# Altium schematic sheet F4_100pin.SchDoc
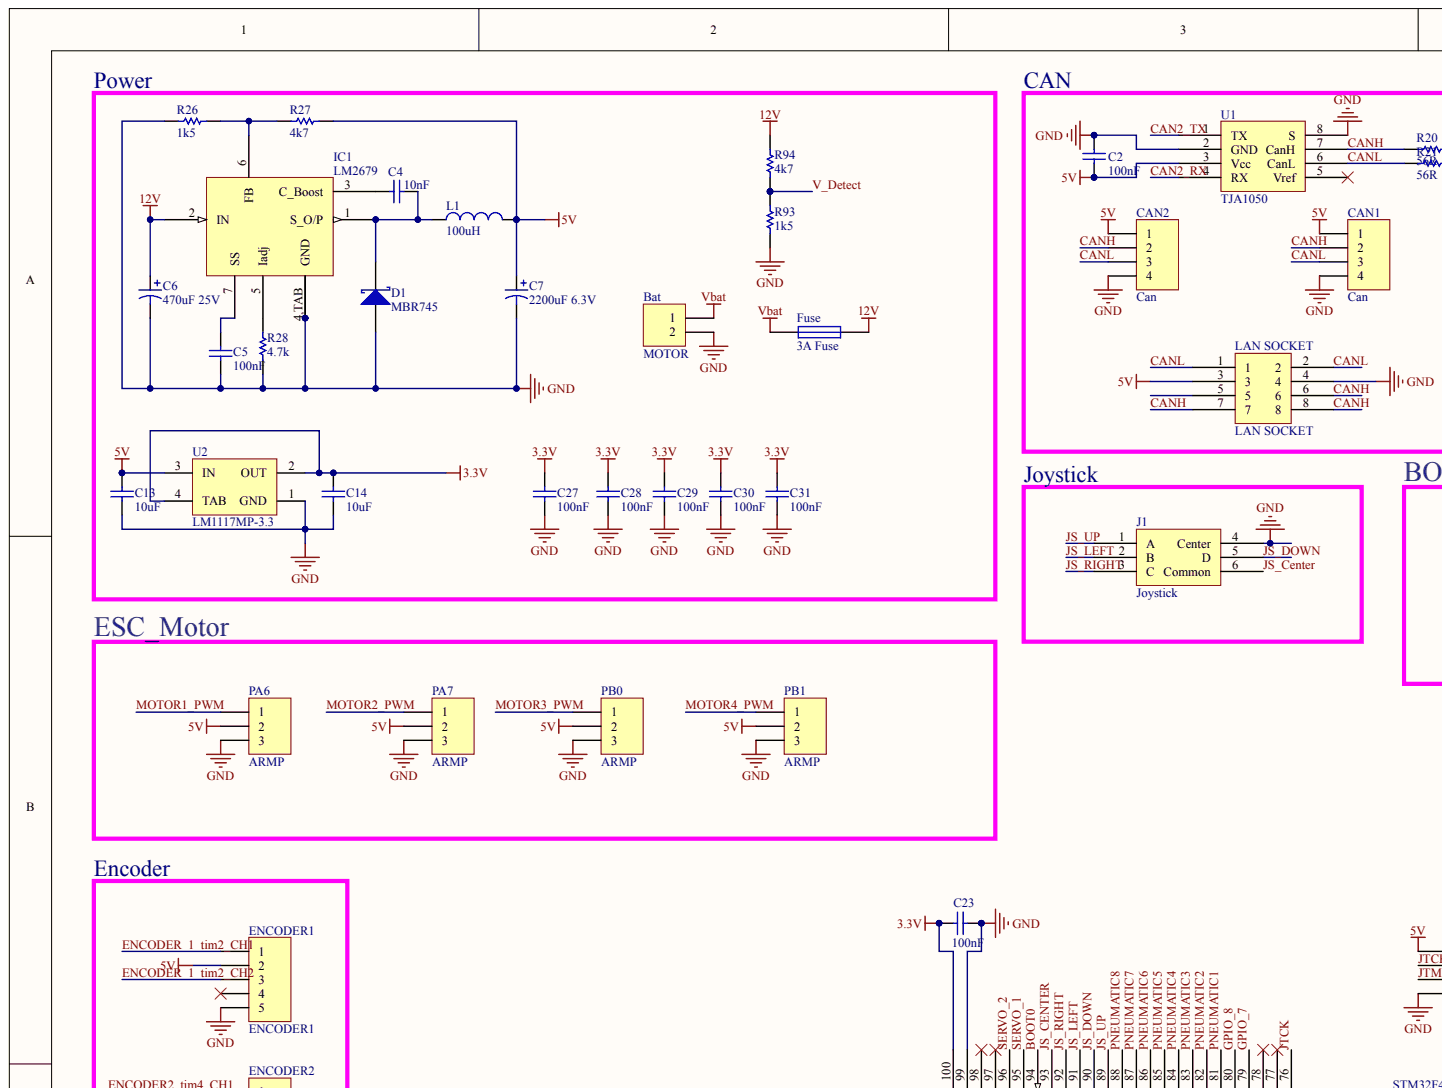
[diagram p0: F4_100pin.SchDoc, top left]
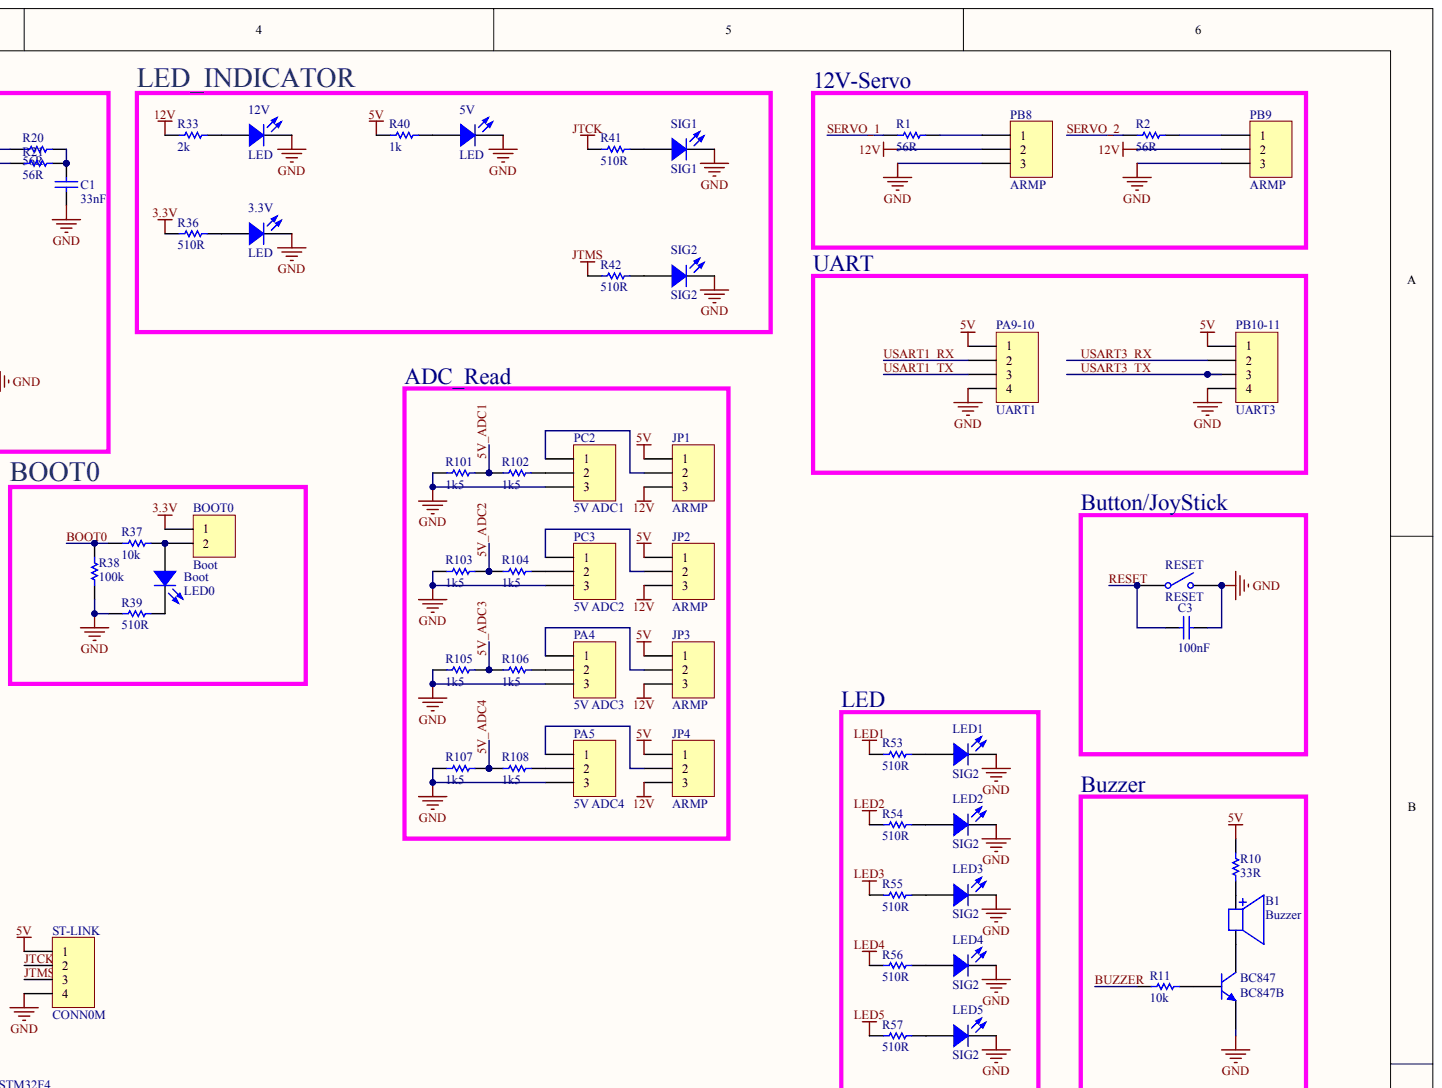
[diagram p1: F4_100pin.SchDoc, top right]
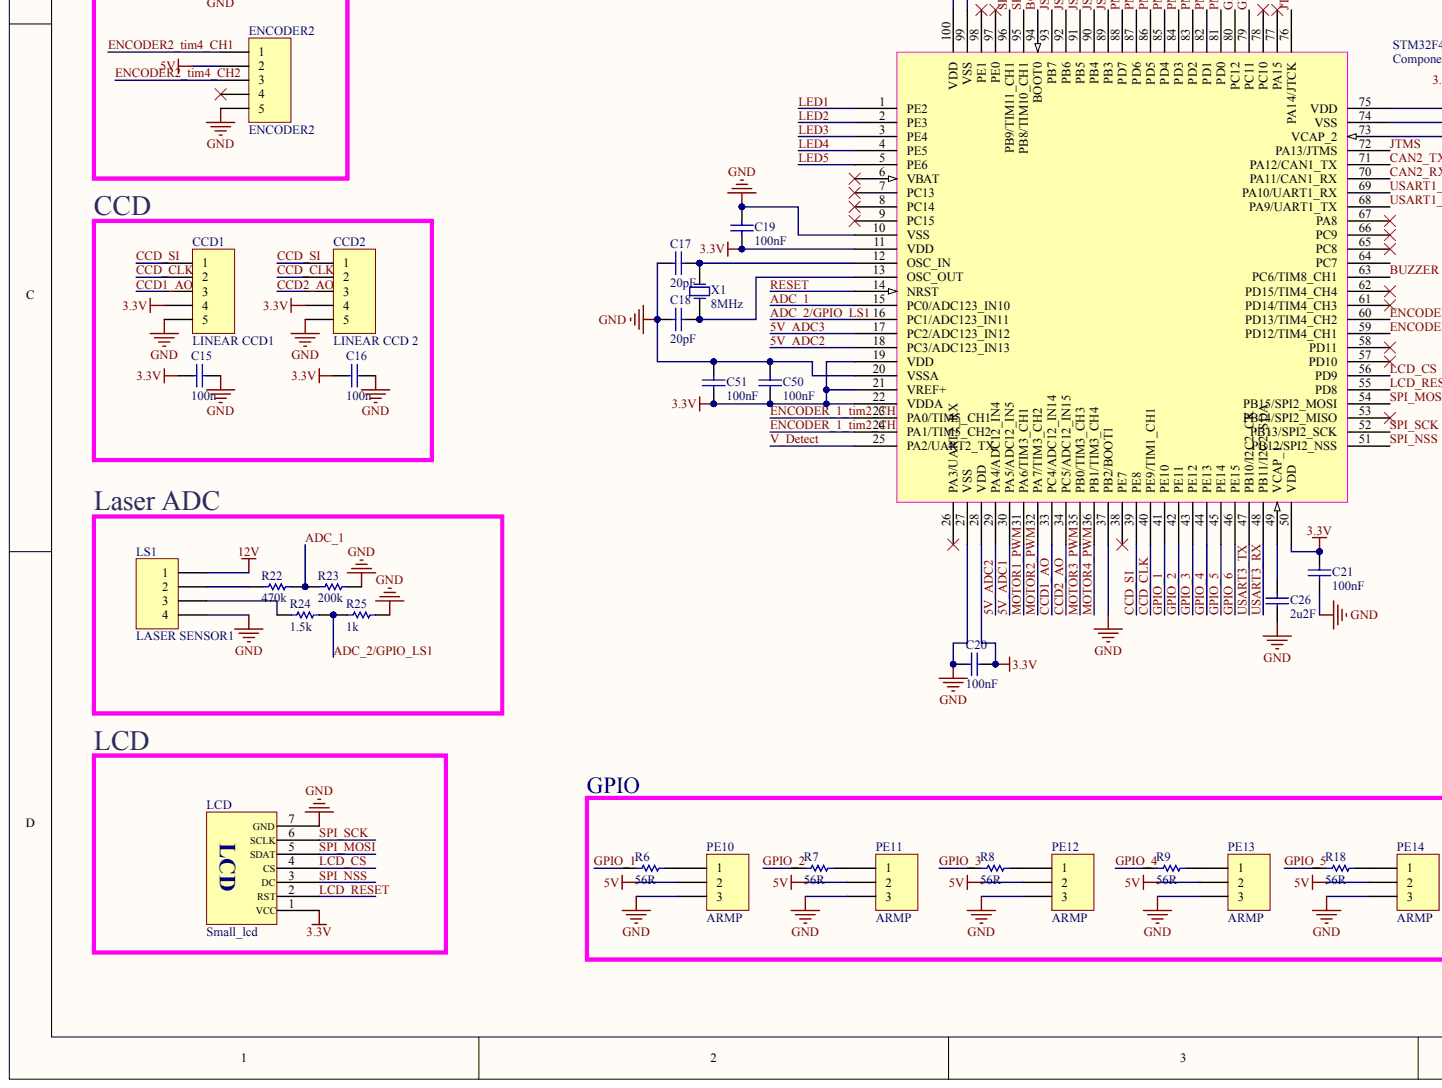
[diagram p2: F4_100pin.SchDoc, bottom left]
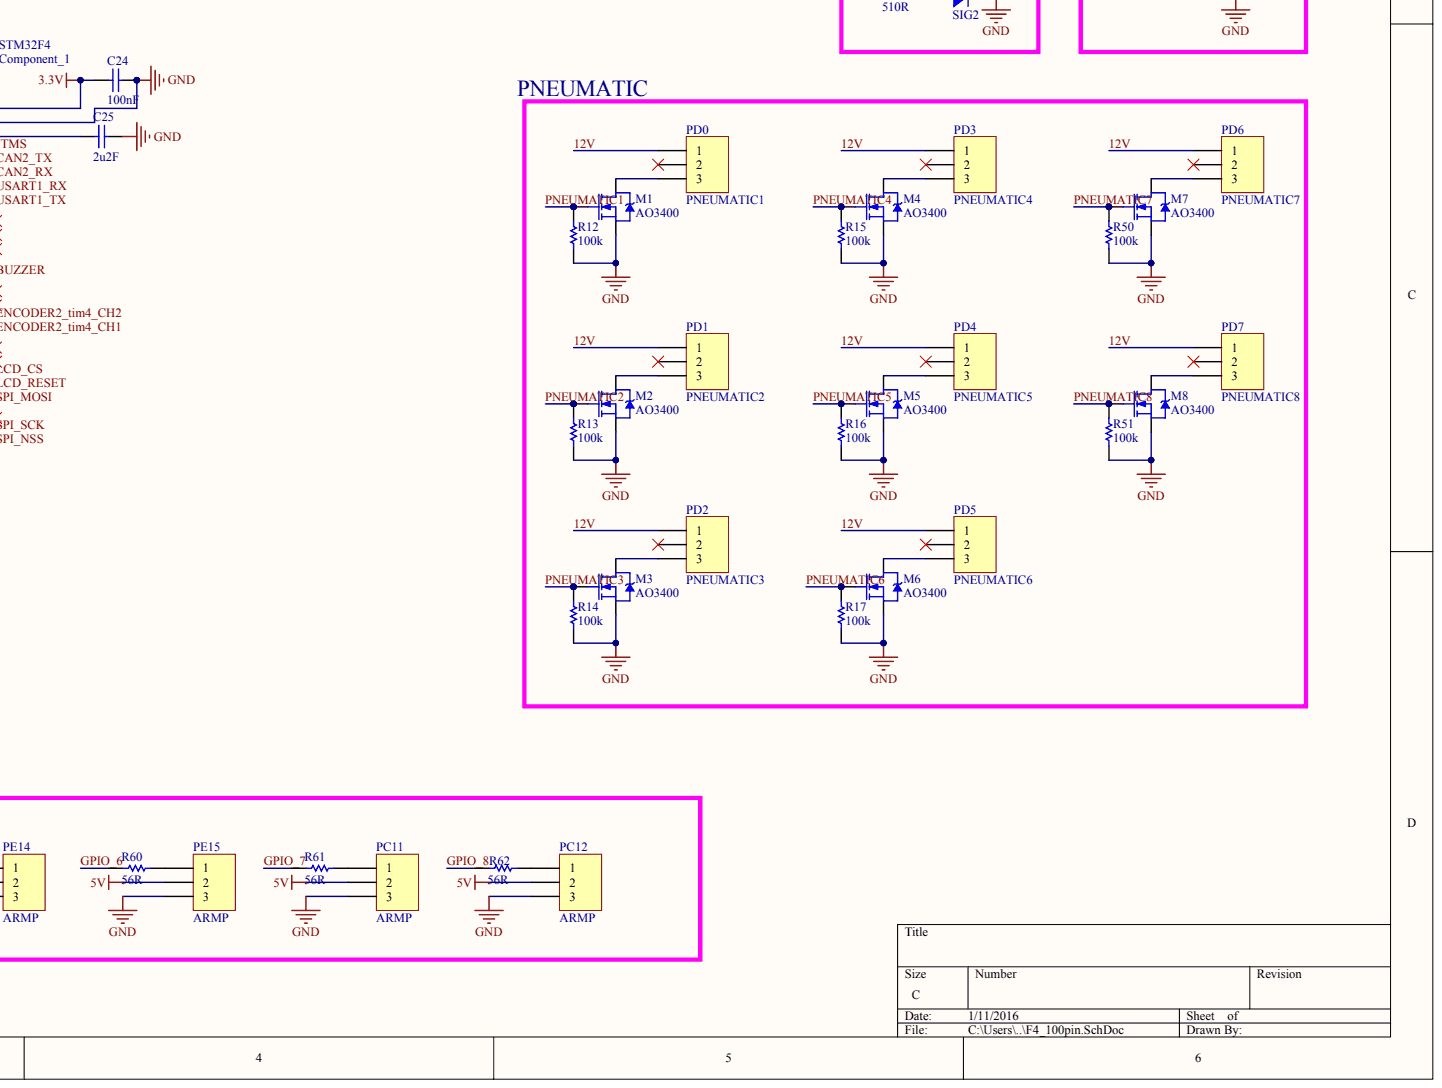
[diagram p3: F4_100pin.SchDoc, bottom right]

## Parts
3.3V  LED
5V  LED
12V  LED
B1  Buzzer
Bat  MOTOR
BC847  BC847B
Boot  LED0
BOOT0  Boot
C1  33nF
C2  100nF
C3  100nF
C4  10nF
C5  100nF
C6  470uF 25V
C7  2200uF 6.3V
C13  10uF
C14  10uF
C15  100n
C16  100n
C17  20pF
C18  20pF
C19  100nF
C20  100nF
C21  100nF
C23  100nF
C24  100nF
C25  2u2F
C26  2u2F
C27  100nF
C28  100nF
C29  100nF
C30  100nF
C31  100nF
C50  100nF
C51  100nF
CAN1  Can
CAN2  Can
CCD1  LINEAR CCD1
CCD2  LINEAR CCD 2
D1  MBR745
ENCODER1  ENCODER1
ENCODER2  ENCODER2
Fuse  3A Fuse
IC1  LM2679
J1  Joystick
JP1  ARMP
JP2  ARMP
JP3  ARMP
JP4  ARMP
L1  value 100uH  (hidden comment: Inductor)
LAN_SOCKET  LAN SOCKET
LCD  Small_lcd
LED1  SIG2
LED2  SIG2
LED3  SIG2
LED4  SIG2
LED5  SIG2
LS1  LASER SENSOR1
M1  AO3400
M2  AO3400
M3  AO3400
M4  AO3400
M5  AO3400
M6  AO3400
M7  AO3400
M8  AO3400
PA4  5V ADC3
PA5  5V ADC4
PA6  ARMP
PA7  ARMP
PA9-10  UART1
PB0  ARMP
PB1  ARMP
PB8  ARMP
PB9  ARMP
PB10-11  UART3
PC2  5V ADC1
PC3  5V ADC2
PC11  ARMP
PC12  ARMP
PD0  PNEUMATIC1
PD1  PNEUMATIC2
PD2  PNEUMATIC3
PD3  PNEUMATIC4
PD4  PNEUMATIC5
PD5  PNEUMATIC6
PD6  PNEUMATIC7
PD7  PNEUMATIC8
PE10  ARMP
PE11  ARMP
PE12  ARMP
PE13  ARMP
PE14  ARMP
PE15  ARMP
R1  56R
R2  56R
R6  56R
R7  56R
R8  56R
R9  56R
R10  33R
R11  10k
R12  100k
R13  100k
R14  100k
R15  100k
R16  100k
R17  100k
R18  56R
R20  56R
R21  56R
R22  470k
R23  200k
R24  1.5k
R25  1k
R26  1k5
R27  4k7
R28  4.7k
R33  2k
R36  510R
R37  10k
R38  100k
R39  510R
R40  1k
R41  510R
R42  510R
R50  100k
R51  100k
R53  510R
R54  510R
R55  510R
R56  510R
R57  510R
R60  56R
R61  56R
R62  56R
R93  1k5
R94  4k7
R101  1k5
R102  1k5
R103  1k5
R104  1k5
R105  1k5
R106  1k5
R107  1k5
R108  1k5
RESET  RESET
SIG1  SIG1
SIG2  SIG2
ST-LINK  CONN0M  (value not drawn)
STM32F4  Component_1
U1  TJA1050
U2  LM1117MP-3.3
X1  8MHz

## Nets
3.3V: BOOT0.1 C14.2 C15.2 C16.2 C19.2 C20.1 C21.1 C23.1 C24.1 C27.1 C28.1 C29.1 C30.1 C31.1 C50.2 C51.2 CCD1.4 CCD2.4 LCD.1(VCC) R36.1 STM32F4.11(VDD) STM32F4.19(VDD) STM32F4.21(VREF+) STM32F4.22(VDDA) STM32F4.28(VDD) STM32F4.50(VDD) STM32F4.75(VDD) STM32F4.100(VDD) U2.2(OUT) U2.4(TAB)
5V: C2.1 C7.1 C13.2 CAN1.1 CAN2.1 ENCODER1.2 ENCODER2.2 JP1.1 JP2.1 JP3.1 JP4.1 L1.2 LAN_SOCKET.3 PA6.2 PA7.2 PA9-10.1 PB0.2 PB1.2 PB10-11.1 PC11.2 PC12.2 PE10.2 PE11.2 PE12.2 PE13.2 PE14.2 PE15.2 R10.2 R27.2 R40.1 ST-LINK.1 U1.3(Vcc) U2.3(IN)
GND: 3.3V.2 5V.2 12V.2 Bat.2 BC847.2 C1.1 C2.2 C3.1 C5.1 C6.2 C7.2 C13.1 C14.1 C15.1 C16.1 C17.1 C18.1 C19.1 C20.2 C21.2 C23.2 C24.2 C25.2 C26.2 C27.2 C28.2 C29.2 C30.2 C31.2 C50.1 C51.1 CAN1.4 CAN2.4 CCD1.5 CCD2.5 D1.1 ENCODER1.5 ENCODER2.5 IC1.0(GND) IC1.4,TAB J1.4(Center) LAN_SOCKET.4 LCD.7(GND) LED1.2 LED2.2 LED3.2 LED4.2 LED5.2 LS1.4 M1.2 M2.2 M3.2 M4.2 M5.2 M6.2 M7.2 M8.2 PA4.3 PA5.3 PA6.3 PA7.3 PA9-10.4 PB0.3 PB1.3 PB8.3 PB9.3 PB10-11.4 PC2.3 PC3.3 PC11.3 PC12.3 PE10.3 PE11.3 PE12.3 PE13.3 PE14.3 PE15.3 R12.1 R13.1 R14.1 R15.1 R16.1 R17.1 R23.2 R25.2 R26.1 R28.1 R38.1 R39.1 R50.1 R51.1 R93.2 R101.1 R103.1 R105.1 R107.1 RESET.2 SIG1.2 SIG2.2 ST-LINK.4 STM32F4.10(VSS) STM32F4.20(VSSA) STM32F4.27(VSS) STM32F4.37(PB2/BOOT1) STM32F4.74(VSS) STM32F4.99(VSS) U1.2(GND) U1.8(S) U2.1(GND)
VBAT: Bat.1 Fuse.1
5V_ADC1: R101.2 R102.1 STM32F4.30(PA5/ADC12_IN5)
5V_ADC2: R103.2 R104.1 STM32F4.18(PC3/ADC123_IN13) STM32F4.29(PA4/ADC12_IN4)
5V_ADC3: R105.2 R106.1 STM32F4.17(PC2/ADC123_IN12)
5V_ADC4: R107.2 R108.1
12V: C6.1 Fuse.2 IC1.2(IN) JP1.3 JP2.3 JP3.3 JP4.3 LS1.1 PB8.2 PB9.2 PD0.1 PD1.1 PD2.1 PD3.1 PD4.1 PD5.1 PD6.1 PD7.1 R33.1 R94.1
ADC_1: R22.2 R23.1 STM32F4.15(PC0/ADC123_IN10)
ADC_2/GPIO_LS1: R24.2 R25.1 STM32F4.16(PC1/ADC123_IN11)
BOOT0: R37.1 R38.2 STM32F4.94(BOOT0)
BUZZER: R11.2 STM32F4.63(PC6/TIM8_CH1)
CAN2_RX: STM32F4.70(PA11/CAN1_RX) U1.4(RX)
CAN2_TX: STM32F4.71(PA12/CAN1_TX) U1.1(TX)
CANH: CAN1.2 CAN2.2 LAN_SOCKET.6 LAN_SOCKET.7 LAN_SOCKET.8 R20.2 U1.7(CanH)
CANL: CAN1.3 CAN2.3 LAN_SOCKET.1 LAN_SOCKET.2 R21.2 U1.6(CanL)
CCD1_AO: CCD1.3 STM32F4.33(PC4/ADC12_IN14)
CCD2_AO: CCD2.3 STM32F4.34(PC5/ADC12_IN15)
CCD_CLK: CCD1.2 CCD2.2 STM32F4.40(PE9/TIM1_CH1)
CCD_SI: CCD1.1 CCD2.1 STM32F4.39(PE8)
ENCODER2_TIM4_CH1: ENCODER2.1 STM32F4.59(PD12/TIM4_CH1)
ENCODER2_TIM4_CH2: ENCODER2.3 STM32F4.60(PD13/TIM4_CH2)
ENCODER_1_TIM2_CH1: ENCODER1.1 STM32F4.23(PA0/TIM5_CH1)
ENCODER_1_TIM2_CH2: ENCODER1.3 STM32F4.24(PA1/TIM5_CH2)
GPIO_1: R6.1 STM32F4.41(PE10)
GPIO_2: R7.1 STM32F4.42(PE11)
GPIO_3: R8.1 STM32F4.43(PE12)
GPIO_4: R9.1 STM32F4.44(PE13)
GPIO_5: R18.1 STM32F4.45(PE14)
GPIO_6: R60.1 STM32F4.46(PE15)
GPIO_7: R61.1 STM32F4.79(PC11)
GPIO_8: R62.1 STM32F4.80(PC12)
JS_CENTER: J1.6(Common) STM32F4.93(PB7)
JS_DOWN: J1.5(D) STM32F4.90(PB4)
JS_LEFT: J1.2(B) STM32F4.91(PB5)
JS_RIGHT: J1.3(C) STM32F4.92(PB6)
JS_UP: J1.1(A) STM32F4.89(PB3)
JTCK: R41.1 ST-LINK.2 STM32F4.76(PA14/JTCK)
JTMS: R42.1 ST-LINK.3 STM32F4.72(PA13/JTMS)
LCD_CS: LCD.4(CS) STM32F4.56(PD9)
LCD_RESET: LCD.2(RST) STM32F4.55(PD8)
LED1: R53.1 STM32F4.1(PE2)
LED2: R54.1 STM32F4.2(PE3)
LED3: R55.1 STM32F4.3(PE4)
LED4: R56.1 STM32F4.4(PE5)
LED5: R57.1 STM32F4.5(PE6)
MOTOR1_PWM: PA6.1 STM32F4.31(PA6/TIM3_CH1)
MOTOR2_PWM: PA7.1 STM32F4.32(PA7/TIM3_CH2)
MOTOR3_PWM: PB0.1 STM32F4.35(PB0/TIM3_CH3)
MOTOR4_PWM: PB1.1 STM32F4.36(PB1/TIM3_CH4)
PNEUMATIC1: M1.1 R12.2 STM32F4.81(PD0)
PNEUMATIC2: M2.1 R13.2 STM32F4.82(PD1)
PNEUMATIC3: M3.1 R14.2 STM32F4.83(PD2)
PNEUMATIC4: M4.1 R15.2 STM32F4.84(PD3)
PNEUMATIC5: M5.1 R16.2 STM32F4.85(PD4)
PNEUMATIC6: M6.1 R17.2 STM32F4.86(PD5)
PNEUMATIC7: M7.1 R50.2 STM32F4.87(PD6)
PNEUMATIC8: M8.1 R51.2 STM32F4.88(PD7)
RESET: C3.2 RESET.1 STM32F4.14(NRST)
SERVO_1: R1.2 STM32F4.95(PB8/TIM10_CH1)
SERVO_2: R2.2 STM32F4.96(PB9/TIM11_CH1)
SPI_MOSI: LCD.5(SDAT) STM32F4.54(PB15/SPI2_MOSI)
SPI_NSS: LCD.3(DC) STM32F4.51(PB12/SPI2_NSS)
SPI_SCK: LCD.6(SCLK) STM32F4.52(PB13/SPI2_SCK)
USART1_RX: PA9-10.2 STM32F4.69(PA10/UART1_RX)
USART1_TX: PA9-10.3 STM32F4.68(PA9/UART1_TX)
USART3_RX: PB10-11.2 STM32F4.48(PB11/I2C2_SDA)
USART3_TX: PB10-11.3 STM32F4.47(PB10/I2C2_CK)
V_DETECT: R93.1 R94.2 STM32F4.25(PA2/UART2_TX)
Net3.3V_1: 3.3V.1 R36.2
Net5V_1: 5V.1 R40.2
Net12V_1: 12V.1 R33.2
NetB1_1: B1.1 R10.1
NetB1_2: B1.2 BC847.3
NetBC847_1: BC847.1 R11.1
NetBOOT0_2: Boot.1 BOOT0.2 R37.2
NetBoot_2: Boot.2 R39.2
NetC1_2: C1.2 R20.1 R21.1
NetC4_1: C4.1 D1.2 IC1.1(S_O/P) L1.1
NetC4_2: C4.2 IC1.3(C_Boost)
NetC5_2: C5.2 IC1.7(SS)
NetC17_2: C17.2 STM32F4.12(OSC_IN) X1.2
NetC18_2: C18.2 STM32F4.13(OSC_OUT) X1.1
NetC25_1: C25.1 STM32F4.73(VCAP_2)
NetC26_1: C26.1 STM32F4.49(VCAP_1)
NetIC1_5: IC1.5(Iadj) R28.2
NetIC1_6: IC1.6(FB) R26.2 R27.1
NetJP1_2: JP1.2 PC2.1
NetJP2_2: JP2.2 PC3.1
NetJP3_2: JP3.2 PA4.1
NetJP4_2: JP4.2 PA5.1
NetLED1_1: LED1.1 R53.2
NetLED2_1: LED2.1 R54.2
NetLED3_1: LED3.1 R55.2
NetLED4_1: LED4.1 R56.2
NetLED5_1: LED5.1 R57.2
NetLS1_2: LS1.2 R22.1
NetLS1_3: LS1.3 R24.1
NetM1_3: M1.3 PD0.3
NetM2_3: M2.3 PD1.3
NetM3_3: M3.3 PD2.3
NetM4_3: M4.3 PD3.3
NetM5_3: M5.3 PD4.3
NetM6_3: M6.3 PD5.3
NetM7_3: M7.3 PD6.3
NetM8_3: M8.3 PD7.3
NetPA4_2: PA4.2 R106.2
NetPA5_2: PA5.2 R108.2
NetPB8_1: PB8.1 R1.1
NetPB9_1: PB9.1 R2.1
NetPC2_2: PC2.2 R102.2
NetPC3_2: PC3.2 R104.2
NetPC11_1: PC11.1 R61.2
NetPC12_1: PC12.1 R62.2
NetPE10_1: PE10.1 R6.2
NetPE11_1: PE11.1 R7.2
NetPE12_1: PE12.1 R8.2
NetPE13_1: PE13.1 R9.2
NetPE14_1: PE14.1 R18.2
NetPE15_1: PE15.1 R60.2
NetR41_2: R41.2 SIG1.1
NetR42_2: R42.2 SIG2.1

Not connected: ENCODER1 4; ENCODER2 4; LAN_SOCKET 5; PD0 2; PD1 2; PD2 2; PD3 2; PD4 2; PD5 2; PD6 2; PD7 2; STM32F4 6(VBAT) 7(PC13) 8(PC14) 9(PC15) 26(PA3/UART2_RX) 38(PE7) 53(PB14/SPI2_MISO) 57(PD10) 58(PD11) 61(PD14/TIM4_CH3) 62(PD15/TIM4_CH4) 64(PC7) 65(PC8) 66(PC9) 67(PA8) 77(PA15) 78(PC10) 97(PE0) 98(PE1); U1 5(Vref)

## Library data of the parts
D1 = (no symbol name): Code - JEDEC: DO-214-AA; Published: 8-Jun-2000; Revision: July-2002: Re-released for DXP Platform.; Publisher: Altium Limited
U2 = (no symbol name): Manufacturer: National Semiconductor; CompType: LDO IC; PackageTopMarking: XYTT*N05A; Published: 27-Nov-2008; DatasheetVersion: Apr-2006; PackageVersion: revB, Apr-2003; PackageReference: MP04A; PackageDescription: Molded SOT-223, 6.50 x 3.56 x 1.6mm Body, 4 Lead, 2.3mm Pitch; PartNumber: LM1117MP-3.3; RoHS: O; PinCount: 4; DigiKeyPartNumber: LM1117MP-3.3CT-ND; Code_JEDEC: TO-261-AA; Code_IPC: SOT223-4; ComponentLink1Description: LM1117 Datasheet; ComponentLink1URL: http://www.national.com/ds/LM/LM1117.pdf; ComponentLink2Description: LM1117 Web Page; ComponentLink2URL: http://www.national.com/pf/LM/LM1117.html; ComponentLink3Description: MP04A Package Page; ComponentLink3URL: http://www.national.com/packaging/folders/mp04a.html; Publisher: Altium Limited
3.3V 5V 12V B1 Bat BC847 Boot BOOT0 C1-C7 C13-C21 C23-C31 C50 C51 CAN1 CAN2 CCD1 CCD2 ENCODER1 ENCODER2 Fuse IC1 J1 JP1-JP4 L1 LAN_SOCKET LCD LED1-LED5 LS1 M1-M8 PA4-PA7 PA9-10 PB0 PB1 PB8 PB9 PB10-11 PC2 PC3 PC11 PC12 PD0-PD7 PE10-PE15 R1 R2 R6-R18 R20-R28 R33 R36-R42 R50 R51 R53-R57 R60-R62 R93 R94 R101-R108 RESET SIG1 SIG2 ST-LINK STM32F4 U1 X1 = (no symbol name)
  3.3V 5V 12V Boot LED1-LED5 SIG1 SIG2: Published: 8-Jun-2000; LatestRevisionDate: 08-Jul-2005; LatestRevisionNote: Mech Layer 1 removed.; PackageReference: LED-1
  Bat BOOT0 CAN1 CAN2 JP1-JP4 LS1 PA4-PA7 PA9-10 PB0 PB1 PB8 PB9 PB10-11 PC2 PC3 PC11 PC12 PD0-PD7 PE10-PE15 ST-LINK: Revision: 17-Jul-2002: Re-released for DXP Platform.
  C1-C5 C15-C21 C23-C31 C50 C51: Published: 8-Jun-2000; LatestRevisionDate: 17-Jul-2002; LatestRevisionNote: Re-released for DXP Platform.; PackageReference: C1206
  C6 C7 R1 R2 R6-R18 R20-R28 R33 R36-R42 R50 R51 R53-R57 R60-R62 R93 R94 R101-R108: Published: 8-Jun-2000; LatestRevisionDate: 17-Jul-2002; LatestRevisionNote: Re-released for DXP Platform.
  C13 C14: Published: 8-Jun-2000; LatestRevisionDate: 17-Jul-2002; LatestRevisionNote: Re-released for DXP Platform.; PackageReference: C1206; : 10uF
  CCD1 CCD2 ENCODER1 ENCODER2: LatestRevisionDate: 17-Jul-2002; LatestRevisionNote: Re-released for DXP Platform.
  Fuse L1 M1-M8 X1: Published: 8-Jun-2000; Revision: July-2002: Re-released for DXP Platform.
  RESET: Published: 24-Mar-1999; Revision: July-2002: Re-released for DXP Platform.
  3.3V 5V 12V Bat Boot BOOT0 C1-C7 C13-C21 C23-C31 C50 C51 CAN1 CAN2 CCD1 CCD2 ENCODER1 ENCODER2 Fuse JP1-JP4 L1 LED1-LED5 LS1 M1-M8 PA4-PA7 PA9-10 PB0 PB1 PB8 PB9 PB10-11 PC2 PC3 PC11 PC12 PD0-PD7 PE10-PE15 R1 R2 R6-R18 R20-R28 R33 R36-R42 R50 R51 R53-R57 R60-R62 R93 R94 R101-R108 RESET SIG1 SIG2 ST-LINK X1: Publisher: Altium Limited
